# Altium schematic sheet Sheet_bod.SchDoc
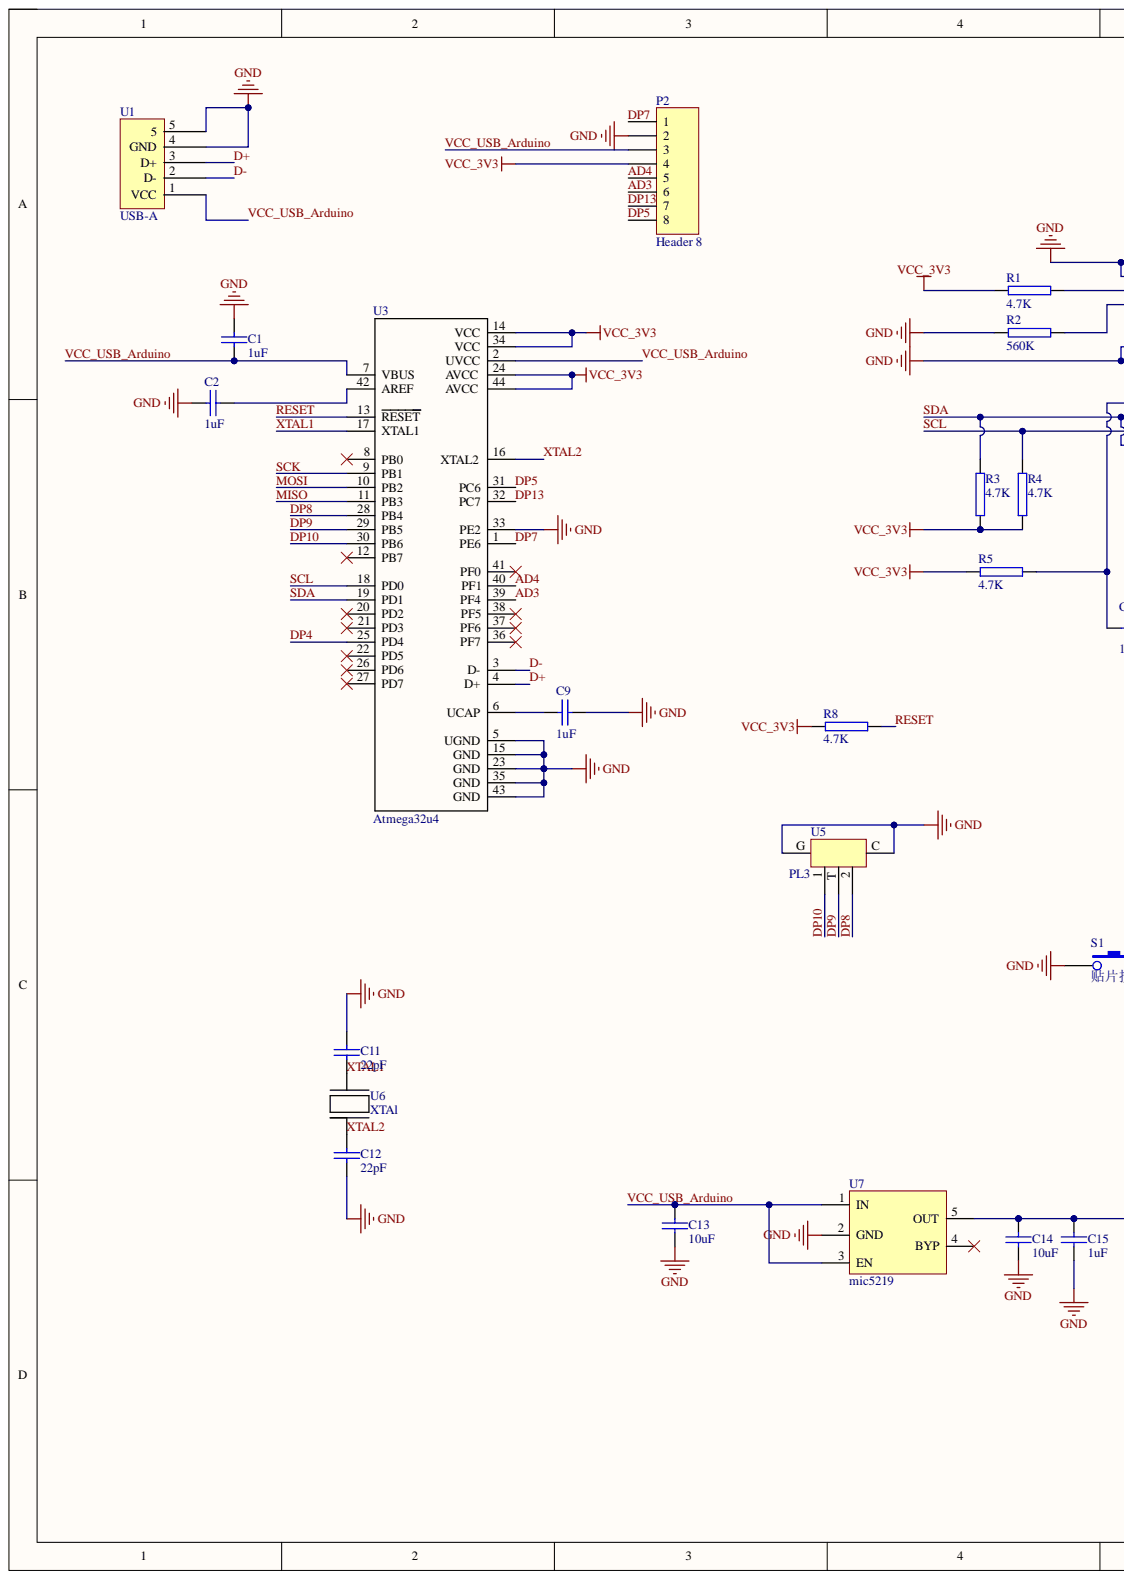
[diagram p0: Sheet_bod.SchDoc, left]
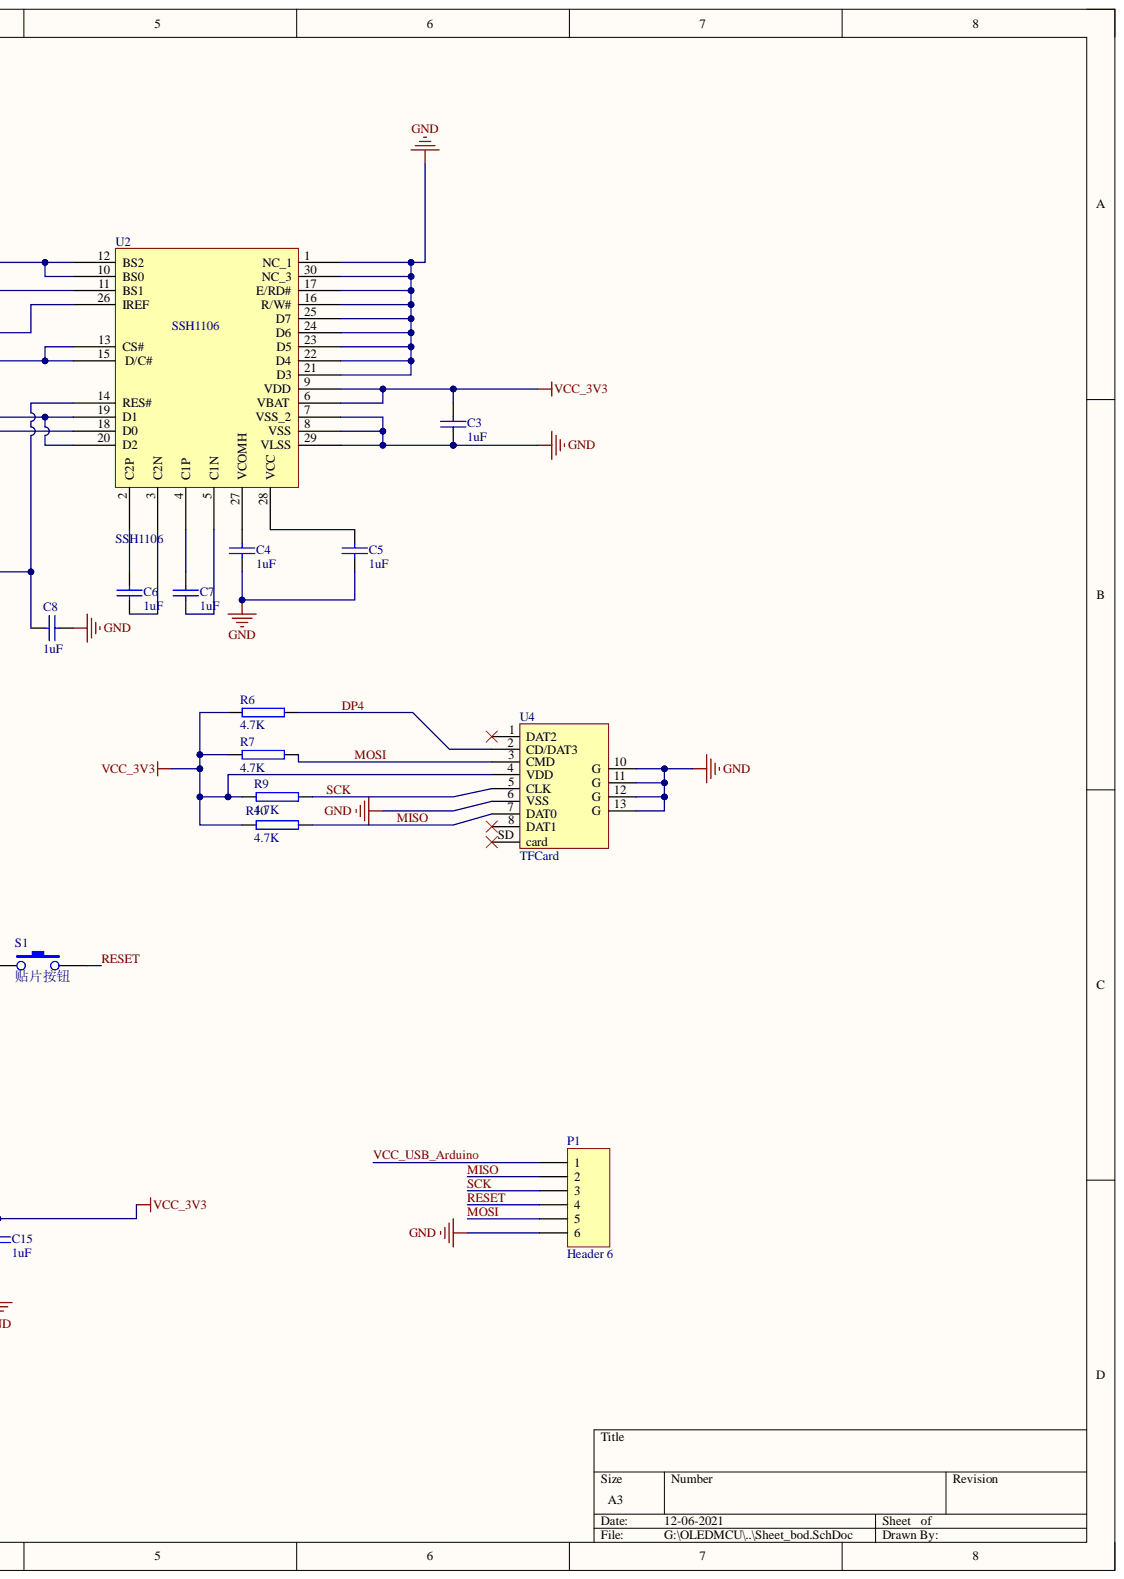
[diagram p1: Sheet_bod.SchDoc, right]

## Parts
C1  1uF  [C0603]
C2  1uF  [C0603]
C3  1uF  [C0603]
C4  1uF  [C0603]
C5  1uF  [C0603]
C6  1uF  [C0603]
C7  1uF  [C0603]
C8  1uF  [C0603]
C9  1uF  [C0603]
C11  22pF  [C0603]
C12  22pF  [C0603]
C13  10uF  [C0603]
C14  10uF  [C0603]
C15  1uF  [C0603]
P1  Header 6  [HDR1X6]
P2  Header 8  [HDR1X8]
R1  4.7K  [R0603]
R2  560K  [R0603]
R3  4.7K  [R0603]
R4  4.7K  [R0603]
R5  4.7K  [R0603]
R6  4.7K  [R0603]
R7  4.7K  [R0603]
R8  4.7K  [R0603]
R9  4.7K  [R0603]
R10  4.7K  [R0603]
S1  贴片按钮  [switch]
U1  USB-A  [USB-A]
U2  SSH1106  [SSH1106]
U3  Atmega32u4  [Atmega32u4]
U4  TFCard  [TFCard]
U5  PL3  [PL3]
U6  XTAl  [XTAL_16mhz]
U7  mic5219  [mic5219]

## Nets
GND: C1.2 C2.2 C3.2 C4.2 C5.2 C8.2 C9.1 C11.1 C12.2 C13.1 C14.1 C15.2 P1.6 P2.2 R2.1 S1.1 U1.4(GND) U1.5 U2.1(NC_1) U2.7(VSS_2) U2.8(VSS) U2.10(BS0) U2.12(BS2) U2.13(CS#) U2.15(D/C#) U2.16(R/W#) U2.17(E/RD#) U2.21(D3) U2.22(D4) U2.23(D5) U2.24(D6) U2.25(D7) U2.29(VLSS) U2.30(NC_3) U3.5(UGND) U3.15(GND) U3.23(GND) U3.33(PE2) U3.35(GND) U3.43(GND) U4.6(VSS) U4.10(G) U4.11(G) U4.12(G) U4.13(G) U5.C U5.G U7.2(GND)
VCC_3V3: C3.1 C14.2 C15.1 P2.4 R1.1 R3.1 R4.1 R5.1 R6.1 R7.1 R8.2 R9.1 R10.1 U2.6(VBAT) U2.9(VDD) U3.14(VCC) U3.24(AVCC) U3.34(VCC) U3.44(AVCC) U4.4(VDD) U7.5(OUT)
AD3: P2.6 U3.39(PF4)
AD4: P2.5 U3.40(PF1)
D+: U1.3(D+) U3.4(D+)
D-: U1.2(D-) U3.3(D-)
DP4: R6.2 U3.25(PD4) U4.2(CD/DAT3)
DP5: P2.8 U3.31(PC6)
DP7: P2.1 U3.1(PE6)
DP8: U3.28(PB4) U5.2
DP9: U3.29(PB5) U5.T
DP10: U3.30(PB6) U5.1
DP13: P2.7 U3.32(PC7)
MISO: P1.2 R10.2 U3.11(PB3) U4.7(DAT0)
MOSI: P1.5 R7.2 U3.10(PB2) U4.3(CMD)
RESET: P1.4 R8.1 S1.2 U3.13(RESET)
SCK: P1.3 R9.2 U3.9(PB1) U4.5(CLK)
SCL: R4.2 U2.18(D0) U3.18(PD0)
SDA: R3.2 U2.19(D1) U2.20(D2) U3.19(PD1)
VCC_USB_Arduino: C1.1 C13.2 P1.1 P2.3 U1.1(VCC) U3.2(UVCC) U3.7(VBUS) U7.1(IN) U7.3(EN)
XTAL1: C11.2 U3.17(XTAL1) U6.2
XTAL2: C12.1 U3.16(XTAL2) U6.1
NetC2_1: C2.1 U3.42(AREF)
NetC4_1: C4.1 U2.27(VCOMH)
NetC5_1: C5.1 U2.28(VCC)
NetC6_1: C6.1 U2.2(C2P)
NetC6_2: C6.2 U2.3(C2N)
NetC7_1: C7.1 U2.4(C1P)
NetC7_2: C7.2 U2.5(C1N)
NetC8_1: C8.1 R5.2 U2.14(RES#)
NetC9_2: C9.2 U3.6(UCAP)
NetR1_2: R1.2 U2.11(BS1)
NetR2_2: R2.2 U2.26(IREF)

Not connected: U3 8(PB0) 12(PB7) 20(PD2) 21(PD3) 22(PD5) 26(PD6) 27(PD7) 36(PF7) 37(PF6) 38(PF5) 41(PF0); U4 1(DAT2) 8(DAT1) SD(card); U7 4(BYP)

## Library data of the parts
C1-C9 C11-C15 = C0603: 贴片电容; Component Kind: Standard; Library Name: Schlib_OLEDMCU.SchLib
P1 = Header 6: Header, 6-Pin; Component Kind: Standard; LatestRevisionDate: 17-Jul-2002; LatestRevisionNote: Re-released for DXP Platform.; Library Name: Miscellaneous Connectors.IntLib; Signal Integrity: Connector; Publisher: Altium Limited
P2 = Header 8: Header, 8-Pin; Component Kind: Standard; LatestRevisionDate: 17-Jul-2002; LatestRevisionNote: Re-released for DXP Platform.; Library Name: Miscellaneous Connectors.IntLib; Signal Integrity: Connector; Publisher: Altium Limited
R1-R10 = R0603: 贴片电阻; Component Kind: Standard; Library Name: Schlib_OLEDMCU.SchLib
S1 = Switch: 3*4*2mm开关; Component Kind: Standard; Library Name: Schlib_OLEDMCU.SchLib
U1 = USB-A: USB公头; Component Kind: Standard; Library Name: Schlib_OLEDMCU.SchLib
U2 = SSH1106: 1.3寸OLED; Component Kind: Standard; Library Name: Schlib_OLEDMCU.SchLib
U3 = Atmega32u4_QFP44: Arduino; Component Kind: Standard; Library Name: Schlib_OLEDMCU.SchLib
U4 = TFCard: TF  卡座; Component Kind: Standard; Library Name: Schlib_OLEDMCU.SchLib
U5 = PL3: 拨轮开关; Component Kind: Standard; Library Name: Schlib_OLEDMCU.SchLib
U6 = XTAL_16Mhz: 贴片晶振Arduino; Component Kind: Standard; Library Name: Schlib_OLEDMCU.SchLib
U7 = Mic5219: 5V转3.3V; Component Kind: Standard; Library Name: Schlib_OLEDMCU.SchLib
